# Altium schematic sheet 驱动_1.SchDoc
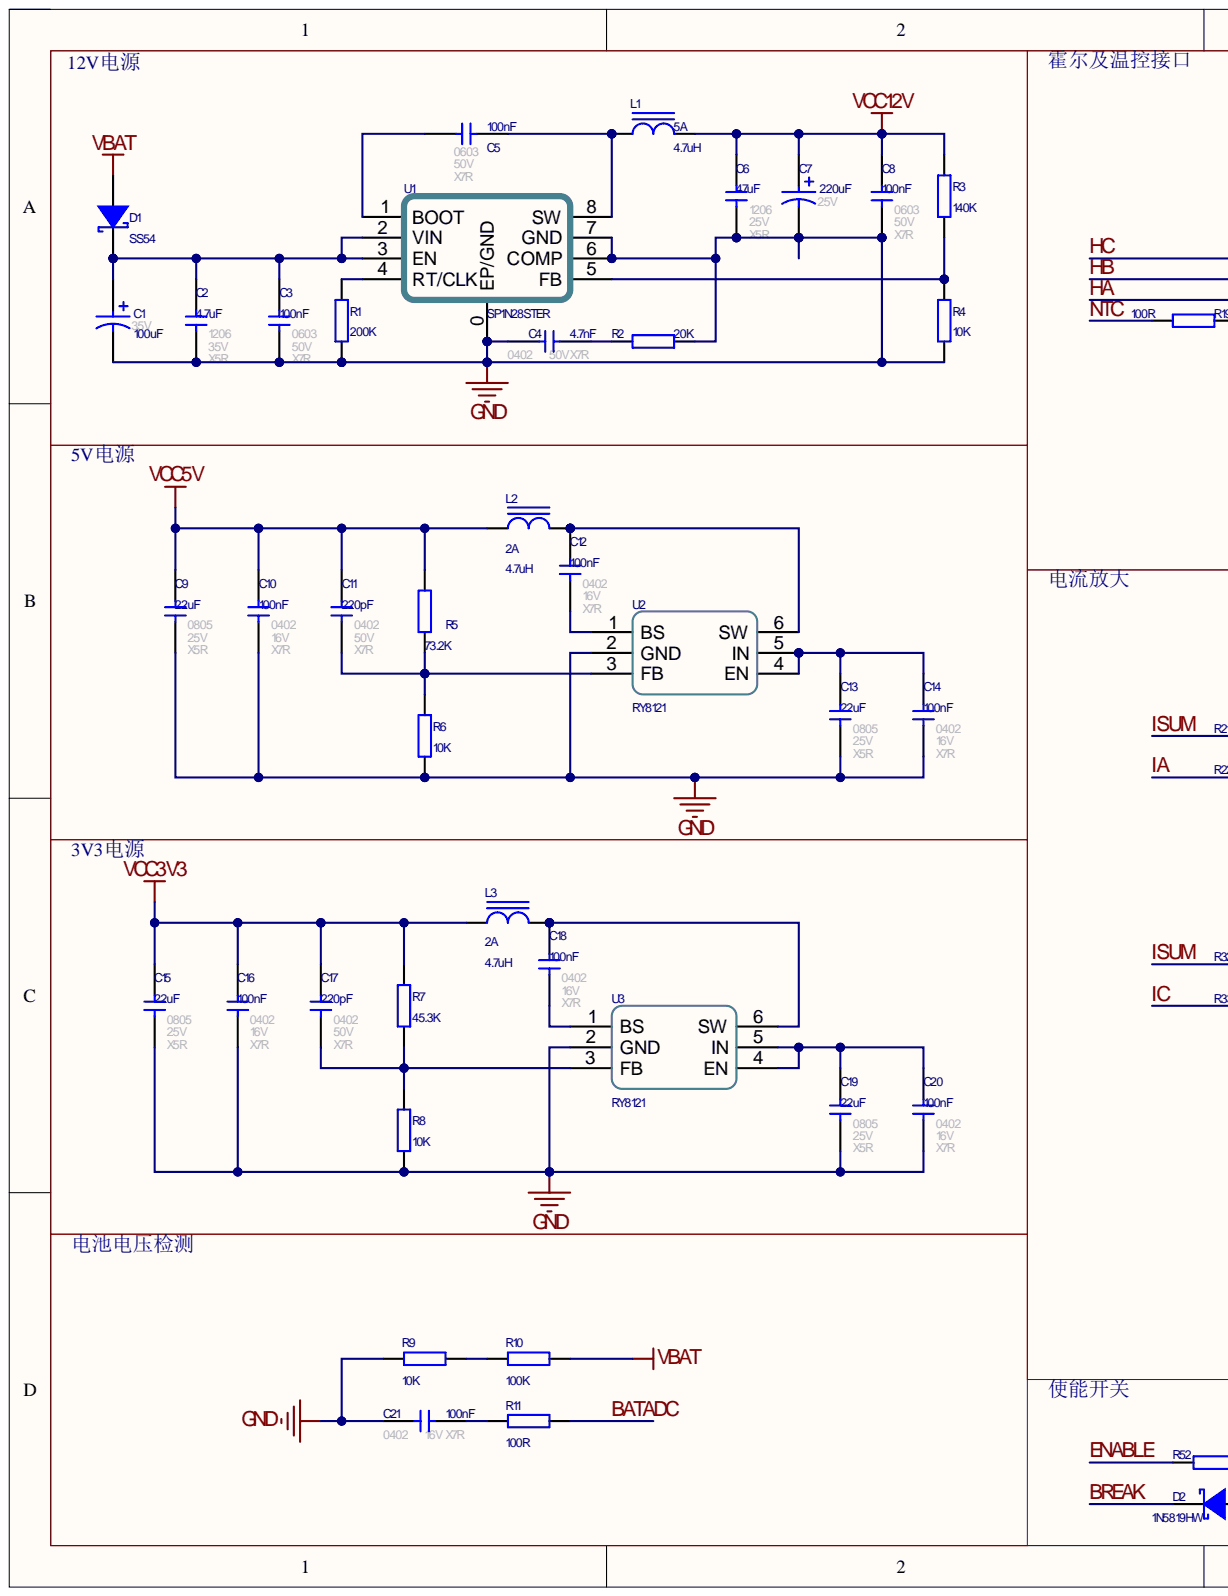
[diagram p0: 驱动_1.SchDoc, left]
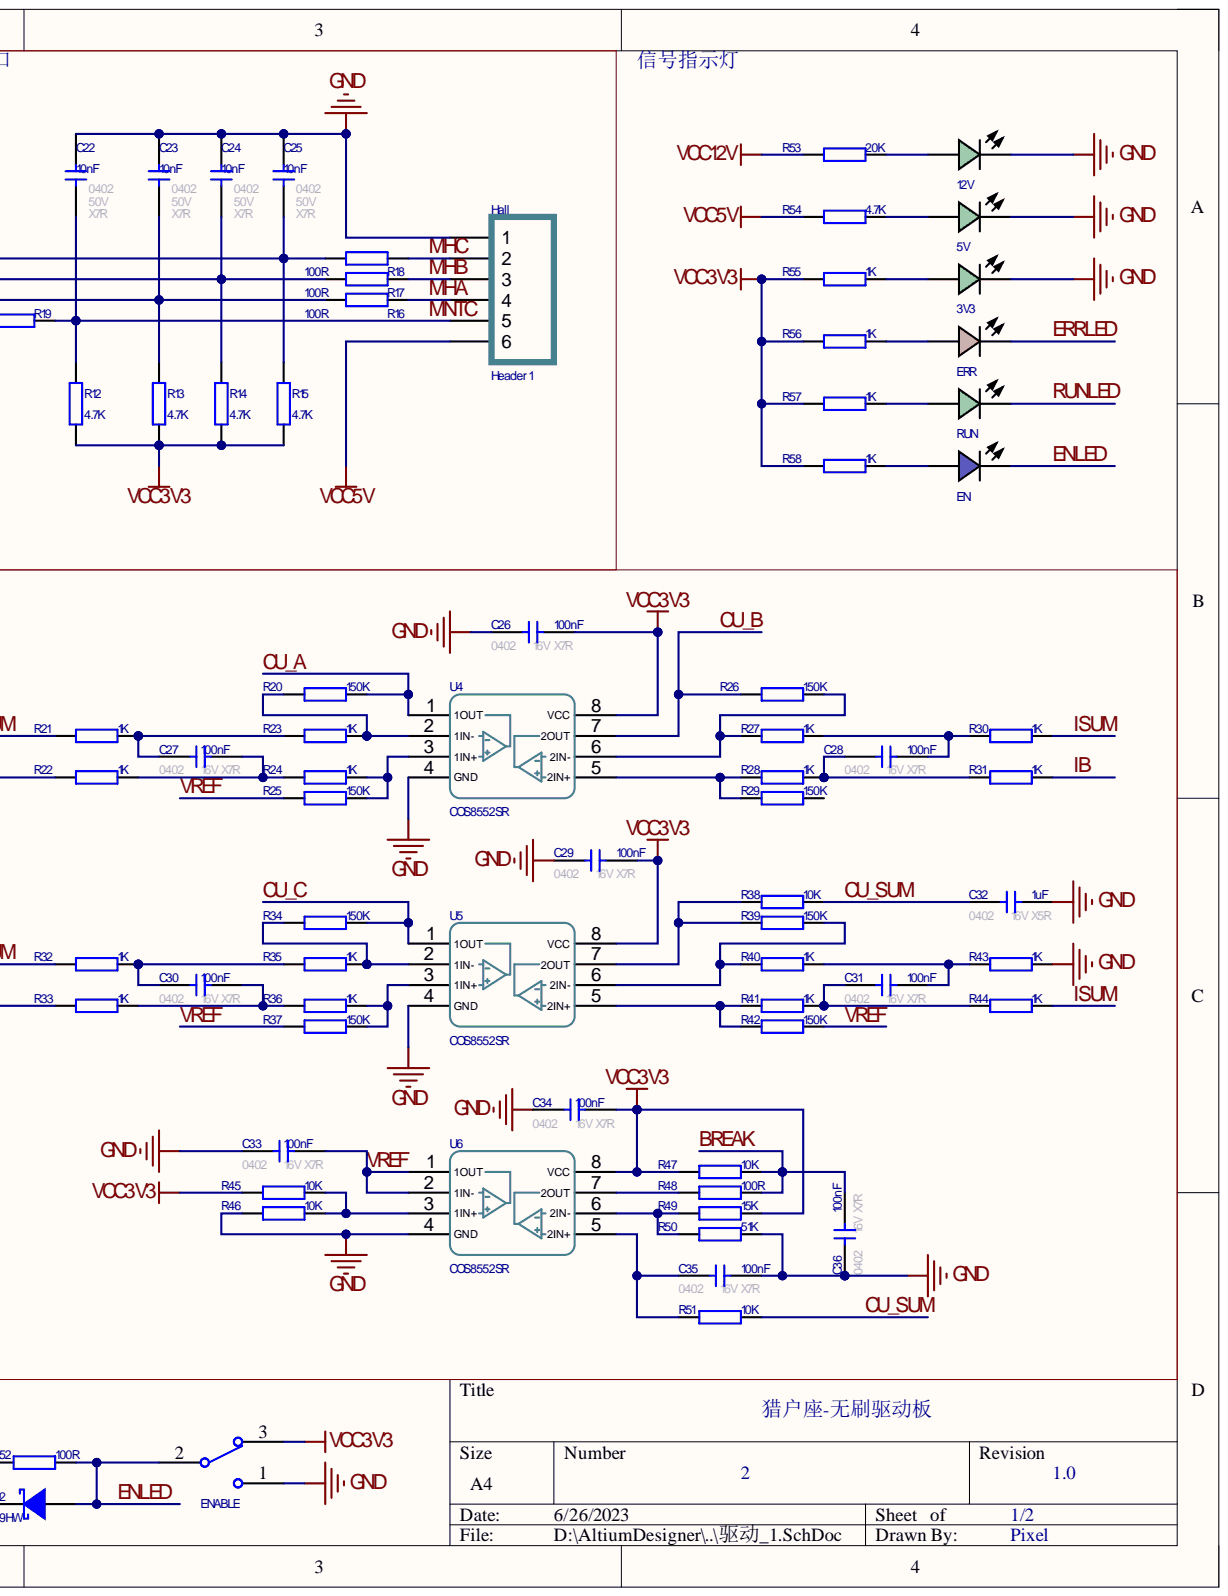
[diagram p1: 驱动_1.SchDoc, right]

## Parts
3V3  翠绿贴片LED  [LEDC1608(0603)-L]  (value not drawn)
5V  翠绿贴片LED  [LEDC1608(0603)-L]  (value not drawn)
12V  翠绿贴片LED  [LEDC1608(0603)-L]  (value not drawn)
C1  value 100uF  Voltage: 35V  [CAP-E-S 6.3]  (hidden comment: 100uF 35V 铝电解)
C2  4.7uF 35V X5R  value 4.7uF  Type: X5R  Voltage: 35V  [1206]
C3  100nF 50V X7R  value 100nF  Type: X7R  Voltage: 50V  [0603]
C4  value 4.7nF  [0402]  (hidden comment: 4.7nF 50V X7R)
C5  100nF 50V X7R  value 100nF  Voltage: 50V  [0603]
C6  47uF 25V X5R  value 47uF  Type: X5R  Voltage: 25V  [1206]
C7  value 220uF  Voltage: 25V  [CAP-E-S 6.3]  (hidden comment: 220uF 25V 铝电解)
C8  100nF 50V X7R  value 100nF  Type: X7R  Voltage: 50V  [0603]
C9  22uF 25V X5R  value 22uF  Type: X5R  Voltage: 25V  [0805]
C10  100nF 16V X7R  value 100nF  Type: X7R  Voltage: 16V  [0402]
C11  220pF 50V X7R  value 220pF  Type: X7R  Voltage: 50V  [0402]
C12  100nF 16V X7R  value 100nF  Type: X7R  Voltage: 16V  [0402]
C13  22uF 25V X5R  value 22uF  Type: X5R  Voltage: 25V  [0805]
C14  100nF 16V X7R  value 100nF  Type: X7R  Voltage: 16V  [0402]
C15  22uF 25V X5R  value 22uF  Type: X5R  Voltage: 25V  [0805]
C16  100nF 16V X7R  value 100nF  Type: X7R  Voltage: 16V  [0402]
C17  220pF 50V X7R  value 220pF  Type: X7R  Voltage: 50V  [0402]
C18  100nF 16V X7R  value 100nF  Type: X7R  Voltage: 16V  [0402]
C19  22uF 25V X5R  value 22uF  Type: X5R  Voltage: 25V  [0805]
C20  100nF 16V X7R  value 100nF  Type: X7R  Voltage: 16V  [0402]
C21  100nF 16V X7R  value 100nF  Type: X7R  Voltage: 16V  [0402]
C22  10nF 50V X7R  value 10nF  Type: X7R  Voltage: 50V  [0402]
C23  10nF 50V X7R  value 10nF  Type: X7R  Voltage: 50V  [0402]
C24  10nF 50V X7R  value 10nF  Type: X7R  Voltage: 50V  [0402]
C25  10nF 50V X7R  value 10nF  Type: X7R  Voltage: 50V  [0402]
C26  100nF 16V X7R  value 100nF  Type: X7R  Voltage: 16V  [0402]
C27  100nF 16V X7R  value 100nF  Type: X7R  Voltage: 16V  [0402]
C28  100nF 16V X7R  value 100nF  Type: X7R  Voltage: 16V  [0402]
C29  100nF 16V X7R  value 100nF  Type: X7R  Voltage: 16V  [0402]
C30  100nF 16V X7R  value 100nF  Type: X7R  Voltage: 16V  [0402]
C31  100nF 16V X7R  value 100nF  Type: X7R  Voltage: 16V  [0402]
C32  1uF 16V X5R  value 1uF  Type: X5R  Voltage: 16V  [0402]
C33  100nF 16V X7R  value 100nF  Type: X7R  Voltage: 16V  [0402]
C34  100nF 16V X7R  value 100nF  Type: X7R  Voltage: 16V  [0402]
C35  100nF 16V X7R  value 100nF  Type: X7R  Voltage: 16V  [0402]
C36  100nF 16V X7R  value 100nF  Type: X7R  Voltage: 16V  [0402]
D1  SS54  [SMA-L[DO-214AC]]
D2  1N5819HW  [SOD-123-L]
EN  蓝色贴片LED  [LEDC1608(0603)-L]  (value not drawn)
ENABLE  SW-SPDT  [SS12D10]  (value not drawn)
ERR  黄色贴片LED  [LEDC1608(0603)-L]  (value not drawn)
Hall  Header 1  [ZH1.5-6P-SH]
L1  4.7uH 5A  value 4.7uH  Imax: 5A  [SLO2520]
L2  4.7uH 2A  value 4.7uH  Imax: 2A  [SLO2520]
L3  4.7uH 2A  value 4.7uH  Imax: 2A  [SLO2520]
R1  200K ±1%  value 200K  [RESC1005[0402]S-N]
R2  value 20K  [RESC1005[0402]S-N]  (hidden comment: 20K ±1%)
R3  140K ±1%  value 140K  [RESC1005[0402]S-N]
R4  value 10K  [RESC1005[0402]S-N]  (hidden comment: 10K ±1%)
R5  value 73.2K  [RESC1005[0402]S-N]  (hidden comment: 73.2K ±1%)
R6  value 10K  [RESC1005[0402]S-N]  (hidden comment: 10K ±1%)
R7  value 45.3K  [RESC1005[0402]S-N]  (hidden comment: 45.3K ±1%)
R8  value 10K  [RESC1005[0402]S-N]  (hidden comment: 10K ±1%)
R9  10K ±1%  value 10K  [RESC1005[0402]S-N]
R10  value 100K  [RESC1005[0402]S-N]  (hidden comment: 100K ±1%)
R11  value 100R  [RESC1005[0402]S-N]  (hidden comment: 100R ±1%)
R12  value 4.7K  [RESC1005[0402]S-N]  (hidden comment: 4.7K ±1%)
R13  value 4.7K  [RESC1005[0402]S-N]  (hidden comment: 4.7K ±1%)
R14  value 4.7K  [RESC1005[0402]S-N]  (hidden comment: 4.7K ±1%)
R15  value 4.7K  [RESC1005[0402]S-N]  (hidden comment: 4.7K ±1%)
R16  100R ±1%  value 100R  [RESC1005[0402]S-N]
R17  100R ±1%  value 100R  [RESC1005[0402]S-N]
R18  100R ±1%  value 100R  [RESC1005[0402]S-N]
R19  value 100R  [RESC1005[0402]S-N]  (hidden comment: 100R ±1%)
R20  value 150K  [RESC1005[0402]S-N]  (hidden comment: 150K ±1%)
R21  value 1K  [RESC1005[0402]S-N]  (hidden comment: 1K ±1%)
R22  value 1K  [RESC1005[0402]S-N]  (hidden comment: 1K ±1%)
R23  value 1K  [RESC1005[0402]S-N]  (hidden comment: 1K ±1%)
R24  value 1K  [RESC1005[0402]S-N]  (hidden comment: 1K ±1%)
R25  150K ±1%  value 150K  [RESC1005[0402]S-N]
R26  value 150K  [RESC1005[0402]S-N]  (hidden comment: 150K ±1%)
R27  value 1K  [RESC1005[0402]S-N]  (hidden comment: 1K ±1%)
R28  value 1K  [RESC1005[0402]S-N]  (hidden comment: 1K ±1%)
R29  150K ±1%  value 150K  [RESC1005[0402]S-N]
R30  value 1K  [RESC1005[0402]S-N]  (hidden comment: 1K ±1%)
R31  value 1K  [RESC1005[0402]S-N]  (hidden comment: 1K ±1%)
R32  value 1K  [RESC1005[0402]S-N]  (hidden comment: 1K ±1%)
R33  value 1K  [RESC1005[0402]S-N]  (hidden comment: 1K ±1%)
R34  value 150K  [RESC1005[0402]S-N]  (hidden comment: 150K ±1%)
R35  value 1K  [RESC1005[0402]S-N]  (hidden comment: 1K ±1%)
R36  value 1K  [RESC1005[0402]S-N]  (hidden comment: 1K ±1%)
R37  150K ±1%  value 150K  [RESC1005[0402]S-N]
R38  value 10K  [RESC1005[0402]S-N]  (hidden comment: 10K ±1%)
R39  150K ±1%  value 150K  [RESC1005[0402]S-N]
R40  value 1K  [RESC1005[0402]S-N]  (hidden comment: 1K ±1%)
R41  value 1K  [RESC1005[0402]S-N]  (hidden comment: 1K ±1%)
R42  150K ±1%  value 150K  [RESC1005[0402]S-N]
R43  value 1K  [RESC1005[0402]S-N]  (hidden comment: 1K ±1%)
R44  value 1K  [RESC1005[0402]S-N]  (hidden comment: 1K ±1%)
R45  10K ±1%  value 10K  [RESC1005[0402]S-N]
R46  10K ±1%  value 10K  [RESC1005[0402]S-N]
R47  value 10K  [RESC1005[0402]S-N]  (hidden comment: 10K ±1%)
R48  100R ±1%  value 100R  [RESC1005[0402]S-N]
R49  15K ±1%  value 15K  [RESC1005[0402]S-N]
R50  51K ±1%  value 51K  [RESC1005[0402]S-N]
R51  value 10K  [RESC1005[0402]S-N]  (hidden comment: 10K ±1%)
R52  value 100R  [RESC1005[0402]S-N]  (hidden comment: 100R ±1%)
R53  value 20K  [RESC1005[0402]S-N]  (hidden comment: 20K ±1%)
R54  value 4.7K  [RESC1005[0402]S-N]  (hidden comment: 4.7K ±1%)
R55  value 1K  [RESC1005[0402]S-N]  (hidden comment: 1K ±1%)
R56  value 1K  [RESC1005[0402]S-N]  (hidden comment: 1K ±1%)
R57  value 1K  [RESC1005[0402]S-N]  (hidden comment: 1K ±1%)
R58  value 1K  [RESC1005[0402]S-N]  (hidden comment: 1K ±1%)
RUN  翠绿贴片LED  [LEDC1608(0603)-L]  (value not drawn)
U1  SP1N28STER  [SOP-8E]
U2  RY8121  [SOT-23-6]
U3  RY8121  [SOT-23-6]
U4  COS8552SR  [SOP-8]
U5  COS8552SR  [SOP-8]
U6  COS8552SR  [SOP-8]

## Nets
GND: 3V3.C 5V.C 12V.C C1.2 C2.1 C3.1 C4.1 C6.1 C7.2 C8.1 C9.1 C10.1 C13.1 C14.1 C15.1 C16.1 C19.1 C20.1 C21.1 C22.2 C23.2 C24.2 C25.2 C26.1 C29.1 C32.2 C33.1 C34.1 C35.2 C36.1 ENABLE.1 Hall.1 R1.1 R2.2 R4.1 R6.1 R8.1 R9.1 R43.1 R46.1 R50.2 U1.0(EP/GND) U1.6(COMP) U1.7(GND) U2.2(GND) U3.2(GND) U4.4(GND) U5.4(GND) U6.4(GND)
VBAT: D1.A R10.2
VCC3V3: C15.2 C16.2 C17.2 C26.2 C29.2 C34.2 ENABLE.3 L3.1 R7.2 R12.1 R13.1 R14.1 R15.1 R45.1 R47.1 R49.2 R55.1 R56.1 R57.1 R58.1 U4.8(VCC) U5.8(VCC) U6.8(VCC)
VCC5V: C9.2 C10.2 C11.2 Hall.6 L2.1 R5.2 R54.1
VCC12V: C6.2 C7.1 C8.2 L1.2 R3.2 R53.1
BATADC: R11.2
BREAK: C36.2 D2.K R47.2 R48.2
CU_A: R20.2 U4.1(1OUT)
CU_B: R26.2 U4.7(2OUT)
CU_C: R34.2 U5.1(1OUT)
CU_SUM: C32.1 R38.2 R51.2
ENABLE: R52.1
ENLED: D2.A EN.C ENABLE.2 R52.2
ERRLED: ERR.C
HA: C23.1 R13.2 R16.1
HB: C24.1 R14.2 R17.1
HC: C25.1 R15.2 R18.1
IA: R22.1
IB: R31.1
IC: R33.1
ISUM: R21.1 R30.1 R32.1 R44.1
MHA: Hall.4 R16.2
MHB: Hall.3 R17.2
MHC: Hall.2 R18.2
MNTC: C22.1 Hall.5 R12.2 R19.2
NTC: R19.1
RUNLED: RUN.C
VREF: C33.2 R25.1 R37.1 R42.1 U6.1(1OUT) U6.2(1IN-)
Net3V3_A: 3V3.A R55.2
Net5V_A: 5V.A R54.2
Net12V_A: 12V.A R53.2
NetC1_1: C1.1 C2.2 C3.2 D1.K U1.2(VIN) U1.3(EN)
NetC4_2: C4.2 R2.1
NetC5_1: C5.1 U1.1(BOOT)
NetC5_2: C5.2 L1.1 U1.8(SW)
NetC11_1: C11.1 R5.1 R6.2 U2.3(FB)
NetC12_1: C12.1 U2.1(BS)
NetC12_2: C12.2 L2.2 U2.6(SW)
NetC13_2: C13.2 C14.2 U2.4(EN) U2.5(IN)
NetC17_1: C17.1 R7.1 R8.2 U3.3(FB)
NetC18_1: C18.1 U3.1(BS)
NetC18_2: C18.2 L3.2 U3.6(SW)
NetC19_2: C19.2 C20.2 U3.4(EN) U3.5(IN)
NetC21_2: C21.2 R11.1
NetC27_1: C27.1 R21.2 R23.1
NetC27_2: C27.2 R22.2 R24.1
NetC28_1: C28.1 R27.1 R30.2
NetC28_2: C28.2 R28.1 R31.2
NetC30_1: C30.1 R32.2 R35.1
NetC30_2: C30.2 R33.2 R36.1
NetC31_1: C31.1 R40.1 R43.2
NetC31_2: C31.2 R41.1 R44.2
NetC35_1: C35.1 R51.1 U6.5(2IN+)
NetEN_A: EN.A R58.2
NetERR_A: ERR.A R56.2
NetR1_2: R1.2 U1.4(RT/CLK)
NetR3_1: R3.1 R4.2 U1.5(FB)
NetR9_2: R9.2 R10.1
NetR20_1: R20.1 R23.2 U4.2(1IN-)
NetR24_2: R24.2 R25.2 U4.3(1IN+)
NetR26_1: R26.1 R27.2 U4.6(2IN-)
NetR28_2: R28.2 R29.2 U4.5(2IN+)
NetR34_1: R34.1 R35.2 U5.2(1IN-)
NetR36_2: R36.2 R37.2 U5.3(1IN+)
NetR38_1: R38.1 R39.2 U5.7(2OUT)
NetR39_1: R39.1 R40.2 U5.6(2IN-)
NetR41_2: R41.2 R42.2 U5.5(2IN+)
NetR45_2: R45.2 R46.2 U6.3(1IN+)
NetR48_1: R48.1 U6.7(2OUT)
NetR49_1: R49.1 R50.1 U6.6(2IN-)
NetR57_2: R57.2 RUN.A

Not connected: R29 1

## Library data of the parts
3V3 5V 12V RUN = LED Chip Green: Component Kind: Standard; Description: 翠绿贴片LED; Price(RMB): 0.00; Published: 8-Jun-2000; Revision: July-2002: Re-released for DXP Platform.; Library Name: 无刷驱动-Infineon-AUIRS2181S-GTM-6S.SchLib; Publisher: Altium Limited
C1 = E-S 100uF 35V 6.3x7.7: 贴片电解电容 100uF 35V 6.3x7.7; Component Kind: Standard; Revision: V1.0; Type: 铝电解; Library Name: 无刷驱动-Infineon-AUIRS2181S-GTM-6S.SchLib
C2 = 4.7uF 1206 35V X5R: 贴片瓷片电容; MLCC; 1206(3216);; Component Kind: Standard; Revision: V1.0; Library Name: 无刷驱动-Infineon-AUIRS2181S-GTM-6S.SchLib
C3 C5 C8 = 100nF 0603 50V X7R: 贴片瓷片电容; MLCC; 0603(1608);; Component Kind: Standard; Revision: V1.0; Library Name: 无刷驱动-Infineon-AUIRS2181S-GTM-6S.SchLib
  C5: Type: X7R
C4 = 4.7nF 0402 50V X7R: 贴片瓷片电容; MLCC; 0402(1005);; Component Kind: Standard; Revision: V1.0; Type: X7R; Voltage: 50V; Library Name: 无刷驱动-Infineon-AUIRS2181S-GTM-6S.SchLib
C6 = 47uF 1206 25V X5R: 贴片瓷片电容; MLCC; 1206(3216);; Component Kind: Standard; Revision: V1.0; Library Name: 无刷驱动-Infineon-AUIRS2181S-GTM-6S.SchLib
C7 = E-S 220uF 25V 6.3x7.7: 贴片电解电容 220uF 25V 6.3x7.7; Component Kind: Standard; Revision: V1.0; Type: 铝电解; Library Name: 无刷驱动-Infineon-AUIRS2181S-GTM-6S.SchLib
C9 C13 C15 C19 = 22uF 0805 25V X5R: 贴片瓷片电容; MLCC; 0805(2012);; Component Kind: Standard; Revision: V1.0; Library Name: 无刷驱动-Infineon-AUIRS2181S-GTM-6S.SchLib
C10 C12 C14 C16 C18 C20 C21 C26-C31 C33-C36 = 100nF 0402 16V X7R: 贴片瓷片电容; MLCC; 0402(1005);; Component Kind: Standard; Revision: V1.0; Library Name: 无刷驱动-Infineon-AUIRS2181S-GTM-6S.SchLib
C11 C17 = 220pF 0402 50V X7R: 贴片瓷片电容; MLCC; 0402(1005);; Component Kind: Standard; Revision: V1.0; Library Name: 无刷驱动-Infineon-AUIRS2181S-GTM-6S.SchLib
C22-C25 = 10nF 0402 50V X7R: 贴片瓷片电容; MLCC; 0402(1005);; Component Kind: Standard; Revision: V1.0; Library Name: 无刷驱动-Infineon-AUIRS2181S-GTM-6S.SchLib
C32 = 1uF 0402 16V X5R: 贴片瓷片电容; MLCC; 0402(1005);; Component Kind: Standard; Revision: V1.0; Library Name: 无刷驱动-Infineon-AUIRS2181S-GTM-6S.SchLib
D1 = SS54: SS54 肖特基二极管; Component Kind: Standard; Revision: V1.0; Value: SS54; Library Name: 无刷驱动-Infineon-AUIRS2181S-GTM-6S.SchLib
D2 = 1N5819HW: 1N5819HW 肖特基二极管; Component Kind: Standard; Revision: V1.0; Value: 1N5819HW; Library Name: 无刷驱动-Infineon-AUIRS2181S-GTM-6S.SchLib
EN = LED Chip Blue: Color: Blue; Component Kind: Standard; Description: 蓝色贴片LED; Price(RMB): 0.00; Published: 8-Jun-2000; Revision: July-2002: Re-released for DXP Platform.; Library Name: 无刷驱动-Infineon-AUIRS2181S-GTM-6S.SchLib; Publisher: Altium Limited
ENABLE = SW-SPDT: 单刀双掷开关 3脚船型、钮子、拨动开关 SPDT Subminiature Toggle Switch; Component Kind: Standard; Price(RMB): 0.000; Published: 24-Mar-1999; Library Name: 无刷驱动-Infineon-AUIRS2181S-GTM-6S.SchLib; Publisher: Altium Limited
ERR = LED Chip Yellow: Component Kind: Standard; Description: 黄色贴片LED; Price(RMB): 0.00; Published: 8-Jun-2000; Revision: July-2002: Re-released for DXP Platform.; Library Name: 无刷驱动-Infineon-AUIRS2181S-GTM-6S.SchLib; Publisher: Altium Limited
Hall = Header 6: Header, 6-Pin; Component Kind: Standard; LatestRevisionDate: 17-Jul-2002; LatestRevisionNote: Re-released for DXP Platform.; Price(RMB): 0.00; Signal Integrity: Connector; Library Name: 无刷驱动-Infineon-AUIRS2181S-GTM-6S.SchLib; Publisher: Altium Limited
L1-L3 = Ind-Power: 功率电感; DCR: .5m; Component Kind: Standard; Price(RMB): 0.000; Published: 8-Jun-2000; Revision: July-2002: Re-released for DXP Platform.; Library Name: 无刷驱动-Infineon-AUIRS2181S-GTM-6S.SchLib; Publisher: Altium Limited
  L2: Value: 4.7uH
R1 = Res-Chip_10: 贴片电阻; Power: 1/8W; Component Kind: Standard; Revision: V1.0; Tolerance: ±1%; Library Name: 无刷驱动-Infineon-AUIRS2181S-GTM-6S.SchLib
R2 R53 = Res-Chip_7: 贴片电阻; Power: 1/8W; Component Kind: Standard; Revision: V1.0; Tolerance: ±1%; Library Name: 无刷驱动-Infineon-AUIRS2181S-GTM-6S.SchLib
R3 = Res-Chip_11: 贴片电阻; Power: 1/8W; Component Kind: Standard; Revision: V1.0; Tolerance: ±1%; Library Name: 无刷驱动-Infineon-AUIRS2181S-GTM-6S.SchLib
R4 R6 R8 R9 R38 R45-R47 R51 = Res-Chip_1: 贴片电阻; Power: 1/8W; Component Kind: Standard; Revision: V1.0; Tolerance: ±1%; Library Name: 无刷驱动-Infineon-AUIRS2181S-GTM-6S.SchLib
R5 = Res-Chip_8: 贴片电阻; Power: 1/8W; Component Kind: Standard; Revision: V1.0; Tolerance: ±1%; Library Name: 无刷驱动-Infineon-AUIRS2181S-GTM-6S.SchLib
R7 = Res-Chip_12: 贴片电阻; Power: 1/8W; Component Kind: Standard; Revision: V1.0; Tolerance: ±1%; Library Name: 无刷驱动-Infineon-AUIRS2181S-GTM-6S.SchLib
R10 = Res-Chip_9: 贴片电阻; Power: 1/8W; Component Kind: Standard; Revision: V1.0; Tolerance: ±1%; Library Name: 无刷驱动-Infineon-AUIRS2181S-GTM-6S.SchLib
R11 R16-R19 R48 R52 = Res-Chip_5: 贴片电阻; Power: 1/8W; Component Kind: Standard; Revision: V1.0; Tolerance: ±1%; Library Name: 无刷驱动-Infineon-AUIRS2181S-GTM-6S.SchLib
R12-R15 R54 = Res-Chip_4: 贴片电阻; Power: 1/8W; Component Kind: Standard; Revision: V1.0; Tolerance: ±1%; Library Name: 无刷驱动-Infineon-AUIRS2181S-GTM-6S.SchLib
R20 R25 R26 R29 R34 R37 R39 R42 = Res-Chip_13: 贴片电阻; Power: 1/8W; Component Kind: Standard; Revision: V1.0; Tolerance: ±1%; Library Name: 无刷驱动-Infineon-AUIRS2181S-GTM-6S.SchLib
R21-R24 R27 R28 R30-R33 R35 R36 R40 R41 R43 R44 R55-R58 = Res-Chip_6: 贴片电阻; Power: 1/8W; Component Kind: Standard; Revision: V1.0; Tolerance: ±1%; Library Name: 无刷驱动-Infineon-AUIRS2181S-GTM-6S.SchLib
R49 = Res-Chip_15: 贴片电阻; Power: 1/8W; Component Kind: Standard; Revision: V1.0; Tolerance: ±1%; Library Name: 无刷驱动-Infineon-AUIRS2181S-GTM-6S.SchLib
R50 = Res-Chip_14: 贴片电阻; Power: 1/8W; Component Kind: Standard; Revision: V1.0; Tolerance: ±1%; Library Name: 无刷驱动-Infineon-AUIRS2181S-GTM-6S.SchLib
U2 U3 = RY8121: 18V 2A 1MHz Synchronous Step-Down Regulator; Component Kind: Standard; Library Name: 无刷驱动-Infineon-AUIRS2181S-GTM-6S.SchLib
U4-U6 = COS8552SR: COS8552SR 二路通用运算放大器; Component Kind: Standard; Library Name: 无刷驱动-Infineon-AUIRS2181S-GTM-6S.SchLib
U1 = SP1N28STER: Component Kind: Standard; Description: SP1N28STER; Revision: V1.0; Value: SP1N28STER; Library Name: 无刷驱动-Infineon-AUIRS2181S-GTM-6S.SchLib
